# Altium schematic sheet mcu.SchDoc
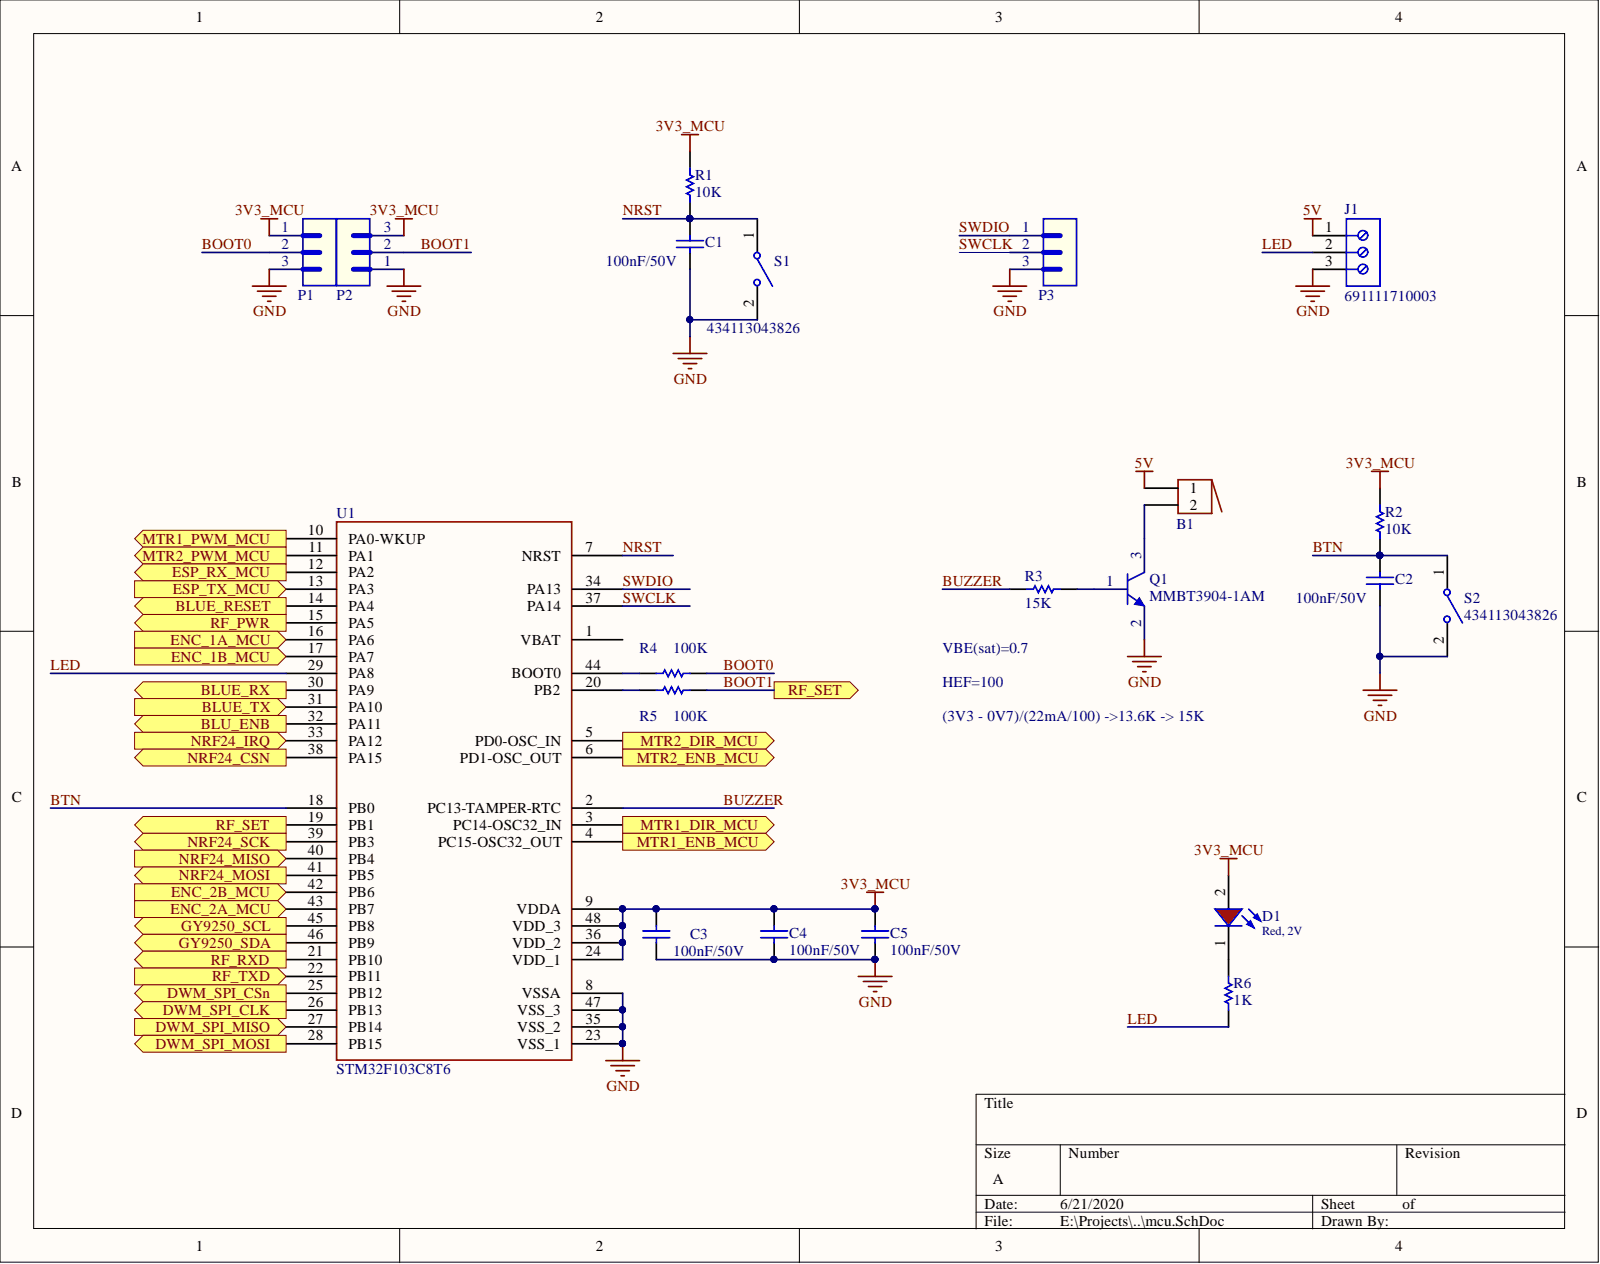
[diagram p0: mcu.SchDoc]

## Parts
B1  Buzzer  [BUZZER]  (value not drawn)
C1  value 100nF/50V  [CAP-SM-0805]  (hidden comment: Cap-100nF-50V-0805)
C2  value 100nF/50V  [CAP-SM-0805]  (hidden comment: Cap-100nF-50V-0805)
C3  value 100nF/50V  [CAP-SM-0805]  (hidden comment: Cap-100nF-50V-0805)
C4  value 100nF/50V  [CAP-SM-0805]  (hidden comment: Cap-100nF-50V-0805)
C5  value 100nF/50V  [CAP-SM-0805]  (hidden comment: Cap-100nF-50V-0805)
D1  Red, 2V  [WL-SMCW_0805]
J1  691111710003  [691111710003]
P1  Header 3  [JP3]  (value not drawn)
P2  Header 3  [JP3]  (value not drawn)
P3  Header 3  [JP3]  (value not drawn)
Q1  MMBT3904-1AM  [SOT23_NEX]
R1  value 10K  [RES-SM-0805]  (hidden comment: Res-10k-0805)
R2  value 10K  [RES-SM-0805]  (hidden comment: Res-10k-0805)
R3  value 15K  [RES-SM-0805]  (hidden comment: Res-15K-0805)
R4  value 100K  [RES-SM-0805]  (hidden comment: Res-100K-0805)
R5  value 100K  [RES-SM-0805]  (hidden comment: Res-100K-0805)
R6  value 1K  [RES-SM-0805]  (hidden comment: Res-1K-0805)
S1  434113043826  [434113043826]
S2  434113043826  [434113043826]
U1  STM32F103C8T6  [LQFP-48]

## Ports
BLU_ENB, BLUE_RESET, BLUE_RX, BLUE_TX, DWM_SPI_CLK, DWM_SPI_CSn, DWM_SPI_MISO, DWM_SPI_MOSI, ENC_1A_MCU, ENC_1B_MCU, ENC_2A_MCU, ENC_2B_MCU, ESP_RX_MCU, ESP_TX_MCU, GY9250_SCL, GY9250_SDA, MTR1_DIR_MCU, MTR1_ENB_MCU, MTR1_PWM_MCU, MTR2_DIR_MCU, MTR2_ENB_MCU, MTR2_PWM_MCU, NRF24_CSN, NRF24_IRQ, NRF24_MISO, NRF24_MOSI, NRF24_SCK, RF_PWR, RF_RXD, RF_SET, RF_TXD

## Nets
3V3_MCU: C3.2 C4.2 C5.2 D1.2 P1.1 P2.3 R1.2 R2.2 U1.9(VDDA) U1.24(VDD_1) U1.36(VDD_2) U1.48(VDD_3)
5V: B1.1 J1.1
GND: C1.1 C2.1 C3.1 C4.1 C5.1 J1.3 P1.3 P2.1 P3.3 Q1.2 S1.2 S2.2 U1.8(VSSA) U1.23(VSS_1) U1.35(VSS_2) U1.47(VSS_3)
BLU_ENB: U1.32(PA11) ; port BLU_ENB
BLUE_RESET: U1.14(PA4) ; port BLUE_RESET
BLUE_RX: U1.30(PA9) ; port BLUE_RX
BLUE_TX: U1.31(PA10) ; port BLUE_TX
BOOT0: P1.2 R4.2
BOOT1: P2.2 R5.1 U1.19(PB1) ; port RF_SET
BTN: C2.2 R2.1 S2.1 U1.18(PB0)
BUZZER: R3.1 U1.2(PC13-TAMPER-RTC)
DWM_SPI_CLK: U1.26(PB13) ; port DWM_SPI_CLK
DWM_SPI_CSn: U1.25(PB12) ; port DWM_SPI_CSn
DWM_SPI_MISO: U1.27(PB14) ; port DWM_SPI_MISO
DWM_SPI_MOSI: U1.28(PB15) ; port DWM_SPI_MOSI
ENC_1A_MCU: U1.16(PA6) ; port ENC_1A_MCU
ENC_1B_MCU: U1.17(PA7) ; port ENC_1B_MCU
ENC_2A_MCU: U1.43(PB7) ; port ENC_2A_MCU
ENC_2B_MCU: U1.42(PB6) ; port ENC_2B_MCU
ESP_RX_MCU: U1.12(PA2) ; port ESP_RX_MCU
ESP_TX_MCU: U1.13(PA3) ; port ESP_TX_MCU
GY9250_SCL: U1.45(PB8) ; port GY9250_SCL
GY9250_SDA: U1.46(PB9) ; port GY9250_SDA
LED: J1.2 R6.1 U1.29(PA8)
MTR1_DIR_MCU: U1.3(PC14-OSC32_IN) ; port MTR1_DIR_MCU
MTR1_ENB_MCU: U1.4(PC15-OSC32_OUT) ; port MTR1_ENB_MCU
MTR1_PWM_MCU: U1.10(PA0-WKUP) ; port MTR1_PWM_MCU
MTR2_DIR_MCU: U1.5(PD0-OSC_IN) ; port MTR2_DIR_MCU
MTR2_ENB_MCU: U1.6(PD1-OSC_OUT) ; port MTR2_ENB_MCU
MTR2_PWM_MCU: U1.11(PA1) ; port MTR2_PWM_MCU
NRF24_CSN: U1.38(PA15) ; port NRF24_CSN
NRF24_IRQ: U1.33(PA12) ; port NRF24_IRQ
NRF24_MISO: U1.40(PB4) ; port NRF24_MISO
NRF24_MOSI: U1.41(PB5) ; port NRF24_MOSI
NRF24_SCK: U1.39(PB3) ; port NRF24_SCK
NRST: C1.2 R1.1 S1.1 U1.7(NRST)
RF_PWR: U1.15(PA5) ; port RF_PWR
RF_RXD: U1.21(PB10) ; port RF_RXD
RF_TXD: U1.22(PB11) ; port RF_TXD
SWCLK: P3.2 U1.37(PA14)
SWDIO: P3.1 U1.34(PA13)
NetB1_2: B1.2 Q1.3
NetD1_1: D1.1 R6.2
NetQ1_1: Q1.1 R3.2
NetR4_1: R4.1 U1.44(BOOT0)
NetR5_2: R5.2 U1.20(PB2)

Not connected: U1 1(VBAT)

## Library data of the parts
C1-C5 = Cap-100nF-50V-0805: CAP CER 0.1UF 50V Y5V 0805; Manufacturer: Samsung Electro-Mechanics; Manufacturer Part Number: CL21F104ZBCNNNC; Component Kind: Standard; Library Name: Capacitor.SchLib; Local Supplier: thegioiic; Local Supplier Link: https://thegioiic.com/products/tu-100nf-0-1uf-50v-0805; Supplier 1: Digikey; Supplier 1 Link: https://www.digikey.com/product-detail/en/samsung-electro-mechanics/CL21F104ZBCNNNC/1276-1007-1-ND/3889093; Supplier 1 Part Number: 1276-1007-1-ND
D1 = 150080RS75000: WL-SMCW SMT Mono-color Chip LED Waterclear, size 0805, Red, 2V, 140deg; Chip Technology: AlInGaP; Dominant Wavelength typ.: 625nm; Luminous Intensity typ.: 150mcd; Peak Wavelength typ.: 630nm; Component Kind: Standard; ComponentLink1Description: Manufacturer; ComponentLink1URL: http://www.we-online.de; ComponentLink2Description: Datasheet; ComponentLink2URL: https://www.we-online.com/catalog/datasheet/150080RS75000.pdf; Emitting Color: Red; Forward Voltage typ.: 2V; Library Name: 150080BS75000_Altium_WL-SMCW_rev20a.IntLib; Mounting Technology: SMT; Operating Temperature: -40 to +85degC; Packaging: Tape and Reel; Part Number: 150080RS75000; Published Date: December 2019; Size: 0805; Viewing Angle: 140deg; Publisher: Wurth Elektronik
J1 = 691111710003: WR-TBL Serie 111 Horizontal Entry Modular, Pressure Clamp, pitch 5mm, 3p; Compliance: Lead free / RoHS; Modularity: Yes; PCB/Cable/Panel: PCB; Pin Number: 3; Rated Current [UL]: 15A; Working Voltage [UL]: 250VAC; Application: Pressure Clamp; Component Kind: Standard; ComponentLink1Description: Manufacturer; ComponentLink1URL: http://www.we-online.de; ComponentLink2Description: Datasheet; ComponentLink2URL: https://katalog.we-online.de/em/datasheet/691111710003.pdf; Library Name: 691322110004_Altium_WR-TBL_rev19a.IntLib; Mounting Technology: THT; Operating Temperature: -40 to +105degC; Packaging: Box; Part Number: 691111710003; Pitch: 5mm; Published Date: October 2019; Type: Horizontal; Publisher: Wurth Elektronik
P1-P3 = Header 3: Header, 3-Pin; Component Kind: Standard; LatestRevisionDate: 17-Jul-2002; LatestRevisionNote: Re-released for DXP Platform.; Library Name: Header-Connector.SchLib; Publisher: Altium Limited
Q1 = MMBT2222A: Component Kind: Standard; Library Name: BJT.SchLib
R1 R2 = Res-10k-0805: RES 10K OHM 1% 1/4W 0805; Manufacturer: Stackpole Electronics Inc; Manufacturer Part Number: RNCP0805FTD10K0; Component Kind: Standard; Library Name: Resistor.SchLib; Local Supplier: thegioiic; Local Supplier Link: https://thegioiic.com/products/dien-tro-10k-ohm-0805-5; Supplier 1: Digikey; Supplier 1 Link: https://www.digikey.com/product-detail/en/stackpole-electronics-inc/RNCP0805FTD10K0/RNCP0805FTD10K0CT-ND/2240601; Supplier 1 Part Number: RNCP0805FTD10K0CT-ND
R3 = Res-15K-0805: RES 15K OHM 1% 1/4W 0805; Manufacturer: TE Connectivity Passive Product; Manufacturer Part Number: CRGCQ0805F15K; Component Kind: Standard; Library Name: Resistor.SchLib; Local Supplier: thegioiic; Local Supplier Link: https://thegioiic.com/products/dien-tro-15k-ohm-0805-1; Supplier 1: Digikey; Supplier 1 Link: https://www.digikey.com/product-detail/en/te-connectivity-passive-product/CRGCQ0805F15K/A129763CT-ND/8577595; Supplier 1 Part Number: A129763CT-ND
R4 R5 = Res-100K-0805: RES 0 OHM JUMPER 0805; Manufacturer: Vishay Dale; Manufacturer Part Number: WSL080500000ZEA9; Component Kind: Standard; Library Name: Resistor.SchLib; Local Supplier: thegioiic; Local Supplier Link: https://thegioiic.com/products/dien-tro-0-ohm-0805-5; Supplier 1: Digikey; Supplier 1 Link: https://www.digikey.com/product-detail/en/vishay-dale/WSL080500000ZEA9/541-3928-1-ND/7423223; Supplier 1 Part Number: 541-3928-1-ND
R6 = Res-1K-0805: RES 1K OHM 1% 1/4W 0805; Manufacturer: Stackpole Electronics Inc; Manufacturer Part Number: RNCP0805FTD1K00; Component Kind: Standard; Library Name: Resistor.SchLib; Local Supplier: thegioiic; Local Supplier Link: https://thegioiic.com/products/dien-tro-1k-ohm-0805-5; Supplier 1: Digikey; Supplier 1 Link: https://www.digikey.com/product-detail/en/stackpole-electronics-inc/RNCP0805FTD1K00/RNCP0805FTD1K00CT-ND/2240568; Supplier 1 Part Number: RNCP0805FTD1K00CT-ND
S1 S2 = 434113043826: WS-TASV J-Bend SMT Tact Switch 6x3.5mm, height 4.3mm, 260gf; Rated Current: 50mA; Rated Voltage: 12VDC; Washable: No; Component Kind: Standard; ComponentLink1Description: Manufacturer; ComponentLink1URL: http://www.we-online.de; ComponentLink2Description: Datasheet; ComponentLink2URL: https://katalog.we-online.com/em/datasheet/434113043826.pdf; Contact Material: Stainless Steel; Contact Plating: Silver; Height: 4.3mm; Library Name: 434111043826_Altium_WS-TASV_rev20a.IntLib; Mounting Technology: SMT; Operating Temperature: -40 to +85degC; Operation Force: 260gf; Packaging: Tape and Reel; Part Number: 434113043826; Published Date: September 2019; Terminal Material: Copper Alloy; Terminal Plating: Silver; Publisher: Wurth Elektronik
U1 = STM32F103C8T6: Manufacturer: ST Electronic; Component Kind: Standard; Local Supplier: TME; Local Supplier Link: http://www.tme.vn/Product.aspx?id=1711#page=pro_info; Local Supplier Part Number: STM32F103C8T6; Manufacture Part Number: STM32F103C8T6; Library Name: PIFWRobot.SchLib
B1 = Buzzer: Component Kind: Standard; Library Name: PIFWRobot.SchLib
